# Altium schematic sheet Sheet1.SchDoc(Sheet1)
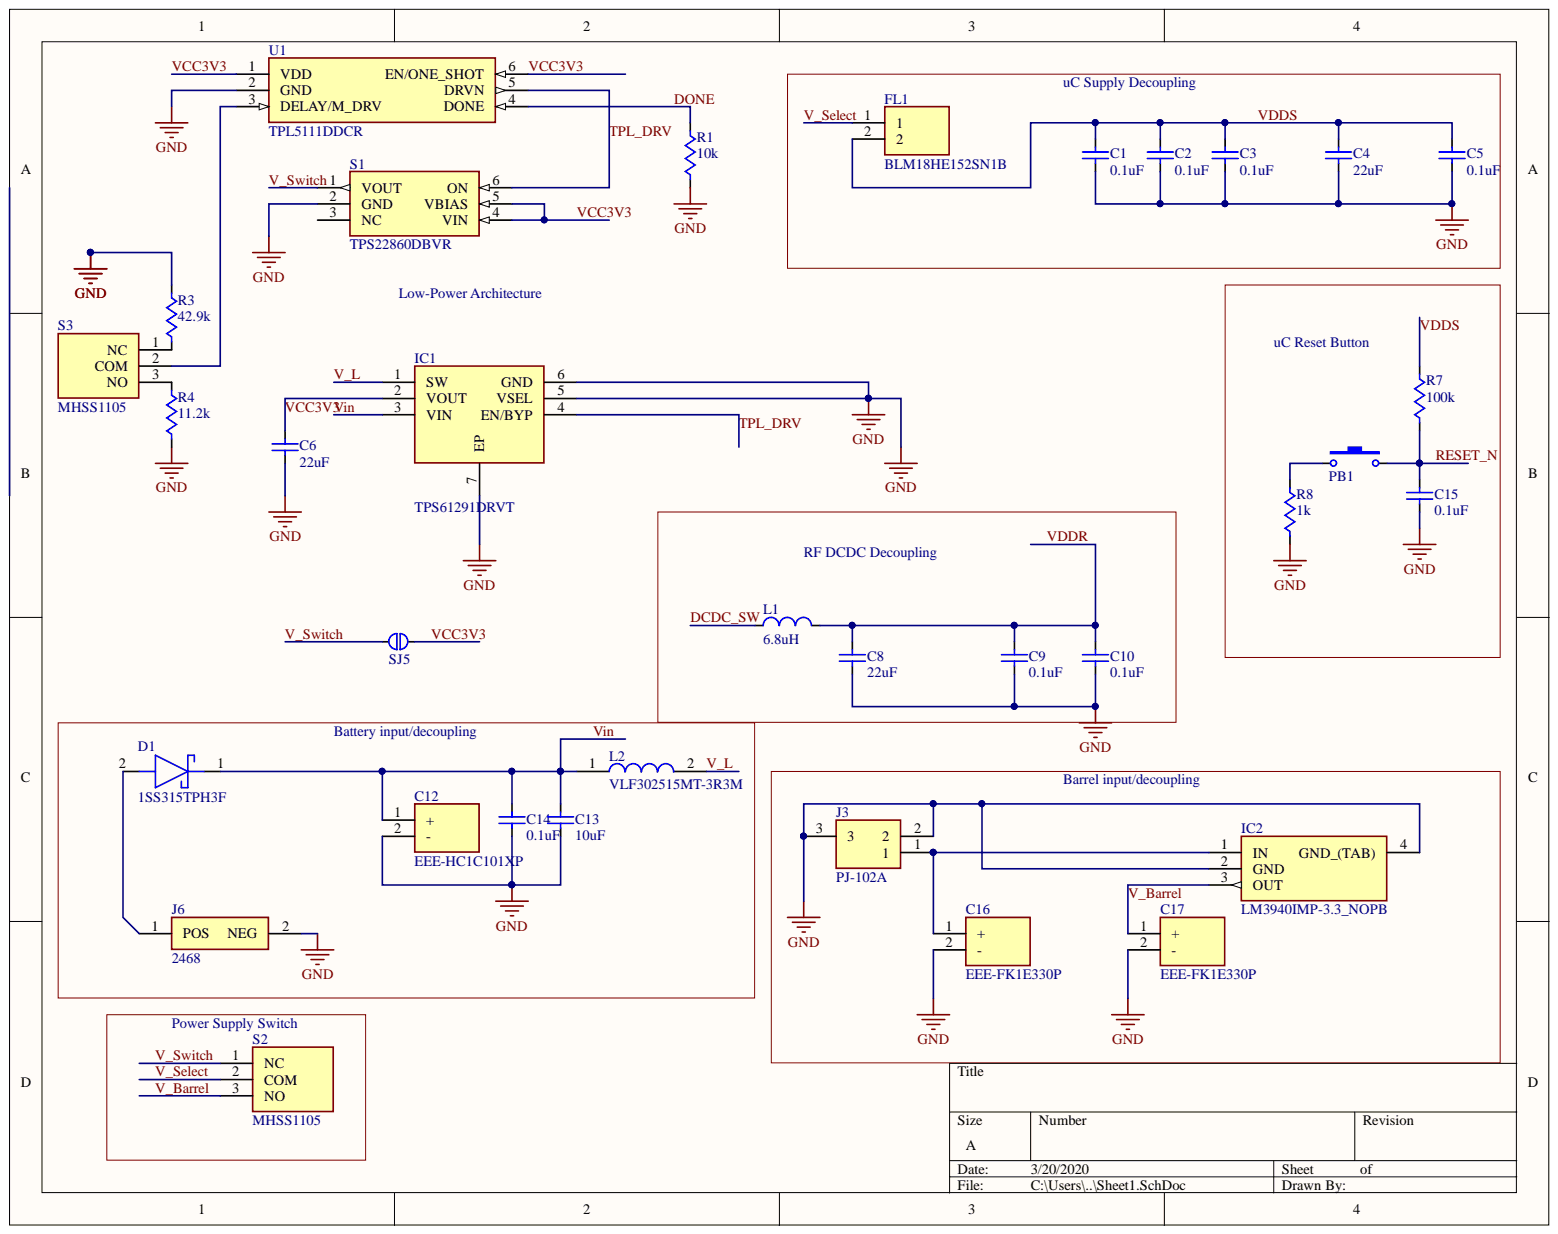
[diagram p0: Sheet1.SchDoc]

## Parts
C1  value 0.1uF  [PASSIVE-CAPACITOR-0603]  (hidden comment: Passive-Capacitor (Generic))
C2  value 0.1uF  [PASSIVE-CAPACITOR-0603]  (hidden comment: Passive-Capacitor (Generic))
C3  value 0.1uF  [PASSIVE-CAPACITOR-0603]  (hidden comment: Passive-Capacitor (Generic))
C4  value 22uF  [PASSIVE-CAPACITOR-0603]
C5  value 0.1uF  [PASSIVE-CAPACITOR-0603]  (hidden comment: Passive-Capacitor (Generic))
C6  value 22uF  [PASSIVE-CAPACITOR-0603]  (hidden comment: Passive-Capacitor (Generic))
C8  value 22uF  [PASSIVE-CAPACITOR-0603]
C9  value 0.1uF  [PASSIVE-CAPACITOR-0603]  (hidden comment: Passive-Capacitor (Generic))
C10  value 0.1uF  [PASSIVE-CAPACITOR-0603]  (hidden comment: Passive-Capacitor (Generic))
C12  EEE-HC1C101XP  [CAPAE660X800N]
C13  value 10uF  [PASSIVE-CAPACITOR-0603]  (hidden comment: Passive-Capacitor (Generic))
C14  value 0.1uF  [PASSIVE-CAPACITOR-0603]  (hidden comment: Passive-Capacitor (Generic))
C15  value 0.1uF  [PASSIVE-CAPACITOR-0603]  (hidden comment: Passive-Capacitor (Generic))
C16  EEE-FK1E330P  [CAPAE660X610N]
C17  EEE-FK1E330P  [CAPAE660X610N]
D1  1SS315TPH3F  [SOD2513X110N]
FL1  BLM18HE152SN1B  [BEADC1608X95N]
IC1  TPS61291DRVT  [SON65P200X200X80-7N]
IC2  LM3940IMP-3.3_NOPB  [SOT230P700X180-4N]
J3  PJ-102A  [PJ-102AH]
J6  2468  [2468]
L1  value 6.8uH  [PASSIVE-INDUCTOR-0603]  (hidden comment: Passive-Inductor (Generic))
L2  VLF302515MT-3R3M  [VLF302515MT]
PB1  Interface-Push-Button  [INTERFACE-PUSH-BUTTON]  (value not drawn)
R1  value 10k  [PASSIVE-RESISTOR-0603]  (hidden comment: Passive-Resistor (Generic))
R3  value 42.9k  [PASSIVE-RESISTOR-0603]  (hidden comment: Passive-Resistor (Generic))
R4  value 11.2k  [PASSIVE-RESISTOR-0603]  (hidden comment: Passive-Resistor (Generic))
R7  value 100k  [PASSIVE-RESISTOR-0603]  (hidden comment: Passive-Resistor (Generic))
R8  value 1k  [PASSIVE-RESISTOR-0603]  (hidden comment: Passive-Resistor (Generic))
S1  TPS22860DBVR  [SOT95P280X145-6N]
S2  MHSS1105  [MHSS1105]
S3  MHSS1105  [MHSS1105]
SJ5  Debug-Solder-Jumper  [DEBUG-SOLDER-JUMPER]  (value not drawn)  (no BOM)
U1  TPL5111DDCR  [SOT95P280X110-6N]

## Nets
GND: C1.1 C2.1 C3.1 C4.2 C5.1 C6.1 C8.2 C9.1 C10.1 C12.2 C13.1 C14.1 C15.2 C16.2 C17.2 IC1.5(VSEL) IC1.6(GND) IC1.7(EP) IC2.2(GND) IC2.4(GND_(TAB)) J3.2 J3.3 J6.2 R1.1 R3.2 R4.1 R8.1 S1.2(GND) U1.2(GND)
DCDC_SW: L1.1
DONE: R1.2 U1.4(DONE)
RESET_N: C15.1 PB1.2 R7.1
TPL_DRV: IC1.4(EN/BYP) S1.6(ON) U1.5(DRVN)
V_Barrel: C17.1 IC2.3(OUT) S2.3
V_L: IC1.1(SW) L2.2
V_Select: FL1.1 S2.2
V_Switch: S1.1(VOUT) S2.1 SJ5.1
VCC3V3: C6.2 IC1.2(VOUT) S1.4(VIN) S1.5(VBIAS) SJ5.2 U1.1(VDD) U1.6(EN/ONE_SHOT)
VDDR: C8.1 C9.2 C10.2 L1.2
VDDS: C1.2 C2.2 C3.2 C4.1 C5.2 FL1.2 R7.2
Vin: C12.1 C13.2 C14.2 D1.1 IC1.3(VIN) L2.1
NetC16_1: C16.1 IC2.1(IN) J3.1
NetD1_2: D1.2 J6.1
NetPB1_1: PB1.1 R8.2
NetR3_1: R3.1 S3.1
NetR4_2: R4.2 S3.3
NetS3_2: S3.2 U1.3(DELAY/M_DRV)
N00004:

Not connected: S1 3(NC)

## Library data of the parts
C1-C6 C8-C10 C13-C15 = Passive-Capacitor (Generic): Generic Capacitor; Supplier 2: Digi-Key; Supplier 1: Mouser; Library Name: MasterLib.IntLib; Component Kind: Standard; Category: Passive
C12 = EEE-HC1C101XP: Capacitor Polarised; Manufacturer_Name: Panasonic; Allied_Number: 70258549; Mouser Price/Stock: https://www.mouser.com/Search/Refine.aspx?Keyword=667-EEE-HC1C101XP; Mouser Part Number: 667-EEE-HC1C101XP; Manufacturer_Part_Number: EEE-HC1C101XP; Height: 8mm; Datasheet Link: http://industrial.panasonic.com/www-cgi/jvcr13pz.cgi?E+PZ+3+ABA0109+EEEHC1C101XP+7+WW; Component Kind: Standard; Allied Price/Stock: https://www.alliedelec.com/panasonic-eee-hc1c101xp/70258549/
C16 C17 = EEE-FK1E330P: Capacitor Polarised; Manufacturer_Name: Panasonic; Allied_Number: 70258257; Mouser Price/Stock: https://www.mouser.com/Search/Refine.aspx?Keyword=667-EEE-FK1E330P; Mouser Part Number: 667-EEE-FK1E330P; Manufacturer_Part_Number: EEE-FK1E330P; Height: 6.1mm; Datasheet Link: https://industrial.panasonic.com/cdbs/www-data/pdf/RDE0000/ABA0000C1181.pdf; Component Kind: Standard; Allied Price/Stock: https://www.alliedelec.com/panasonic-eee-fk1e330p/70258257/
D1 = 1SS315TPH3F: Schottky Diode; Manufacturer_Name: Toshiba; Mouser Price/Stock: https://www.mouser.com/Search/Refine.aspx?Keyword=757-1SS315TPH3F; Mouser Part Number: 757-1SS315TPH3F; Manufacturer_Part_Number: 1SS315TPH3F; Height: 1.1mm; Datasheet Link: http://toshiba.semicon-storage.com/info/docget.jsp?did=2666&prodName=1SS315; Component Kind: Standard
FL1 = BLM18HE152SN1B: Filter; Manufacturer_Name: Murata Electronics; Mouser Price/Stock: https://www.mouser.com/Search/Refine.aspx?Keyword=N%2FA; Mouser Part Number: N/A; Manufacturer_Part_Number: BLM18HE152SN1B; Height: 0.95mm; Datasheet Link: https://www.murata.com/en-us/products/productdetail?partno=BLM18HE152SN1%23; Component Kind: Standard
IC1 = TPS61291DRVT: Integrated Circuit; Manufacturer_Name: Texas Instruments; RS Price/Stock: http://uk.rs-online.com/web/p/products/9143112P; RS Part Number: 9143112P; Mouser Price/Stock: https://www.mouser.com/Search/Refine.aspx?Keyword=595-TPS61291DRVT; Mouser Part Number: 595-TPS61291DRVT; Manufacturer_Part_Number: TPS61291DRVT; Height: 0.8mm; Datasheet Link: http://uk.rs-online.com/web/p/products/9143112P; Component Kind: Standard; Library Name: Enviornmental_Sensor.IntLib
IC2 = LM3940IMP-3.3_NOPB: Integrated Circuit; Manufacturer_Part_Number: LM3940IMP-3.3/NOPB; Manufacturer_Name: Texas Instruments; RS Price/Stock: http://uk.rs-online.com/web/p/products/5361546P; RS Part Number: 5361546P; Mouser Price/Stock: https://www.mouser.com/Search/Refine.aspx?Keyword=926-LM3940IMP3.3NOPB; Mouser Part Number: 926-LM3940IMP3.3NOPB; Height: 1.8mm; Datasheet Link: http://www.ti.com/lit/ds/symlink/lm3940.pdf; Component Kind: Standard
J3 = PJ-102A: Connector; Manufacturer_Name: CUI; Mouser Price/Stock: https://www.mouser.com/Search/Refine.aspx?Keyword=490-PJ-102A; Mouser Part Number: 490-PJ-102A; Manufacturer_Part_Number: PJ-102A; Datasheet Link: https://www.cui.com/product/resource/pj-102a.pdf; Component Kind: Standard; Library Name: EnvironmentalSensor.IntLib
J6 = 2468: Connector; Manufacturer_Name: Keystone Electronics; Allied_Number: 70182756; RS Price/Stock: http://uk.rs-online.com/web/p/products/2794965; RS Part Number: 2794965; Mouser Price/Stock: https://www.mouser.com/Search/Refine.aspx?Keyword=534-2468; Mouser Part Number: 534-2468; Manufacturer_Part_Number: 2468; Datasheet Link: http://docs-emea.rs-online.com/webdocs/002e/0900766b8002ef75.pdf; Component Kind: Standard; Allied Price/Stock: http://www.alliedelec.com/keystone-electronics-2468/70182756/
L1 = Passive-Inductor (Generic): Generic Inductor; Supplier 2: Digi-Key; Supplier 1: Mouser; Library Name: MasterLib.IntLib; Component Kind: Standard; Category: Passive
L2 = VLF302515MT-3R3M: Inductor; Manufacturer_Name: TDK; Mouser Price/Stock: https://www.mouser.com/Search/Refine.aspx?Keyword=810-VLF302515MT-3R3M; Mouser Part Number: 810-VLF302515MT-3R3M; Manufacturer_Part_Number: VLF302515MT-3R3M; Datasheet Link: https://product.tdk.com/info/en/documents/wdcatalog/withdrawn_inductor_commercial_power_vlf302515mt_en.pdf; Component Kind: Standard
PB1 = Interface-Push-Button: 2.5mm Tall, 2.5N Force, Straight, SMD, Push Button; Manufacturer Part Number: PTS820 J25K SMTR LFS; Manufacturer: C&K Components; Value: Single; Through Hole (y/n): N; Supplier Part Number 2: CKN10897CT-ND; Supplier Part Number 1: 611-PTS820J25KSMTR; Supplier 2: Digi-Key; Supplier 1: Mouser; Package: SMD-6; Library Name: MasterLib.IntLib; ComponentLink1URL: https://www.mouser.com/datasheet/2/60/pts820-1382642.pdf; ComponentLink1Description: Datasheet; Component Kind: Standard; Category: Interface
R1 R3 R4 R7 R8 = Passive-Resistor (Generic): Generic Resistor; Through Hole (y/n): N; Supplier 2: Digi-Key; Supplier 1: Mouser; Library Name: MasterLib.IntLib; Component Kind: Standard; Category: Passive
S1 = TPS22860DBVR: Switch; Manufacturer_Name: Texas Instruments; Mouser Price/Stock: https://www.mouser.com/Search/Refine.aspx?Keyword=595-TPS22860DBVR; Mouser Part Number: 595-TPS22860DBVR; Manufacturer_Part_Number: TPS22860DBVR; Height: 1.45mm; Datasheet Link: http://www.ti.com/lit/gpn/tps22860; Component Kind: Standard; Library Name: Enviornmental_Sensor.IntLib
S2 S3 = MHSS1105: Switch; Manufacturer_Name: Apem; Mouser Price/Stock: https://www.mouser.com/Search/Refine.aspx?Keyword=642-MHSS1105; Mouser Part Number: 642-MHSS1105; Manufacturer_Part_Number: MHSS1105; Height: 4mm; Datasheet Link: https://componentsearchengine.com/Datasheets/2/MHSS1105.pdf; Component Kind: Standard
SJ5 = Debug-Solder-Jumper: 3.5mm Diameter, Solder Jumper Pad; Library Name: MasterLib.IntLib; Category: Debug
U1 = TPL5111DDCR: Undefined or Miscellaneous; Manufacturer_Name: Texas Instruments; Mouser Price/Stock: https://www.mouser.com/Search/Refine.aspx?Keyword=595-TPL5111DDCR; Mouser Part Number: 595-TPL5111DDCR; Manufacturer_Part_Number: TPL5111DDCR; Height: 1.1mm; Datasheet Link: http://www.ti.com/lit/ds/symlink/tpl5111.pdf; Component Kind: Standard; Library Name: Enviornmental_Sensor.IntLib
